# Altium schematic sheet ESP32P4.SchDoc
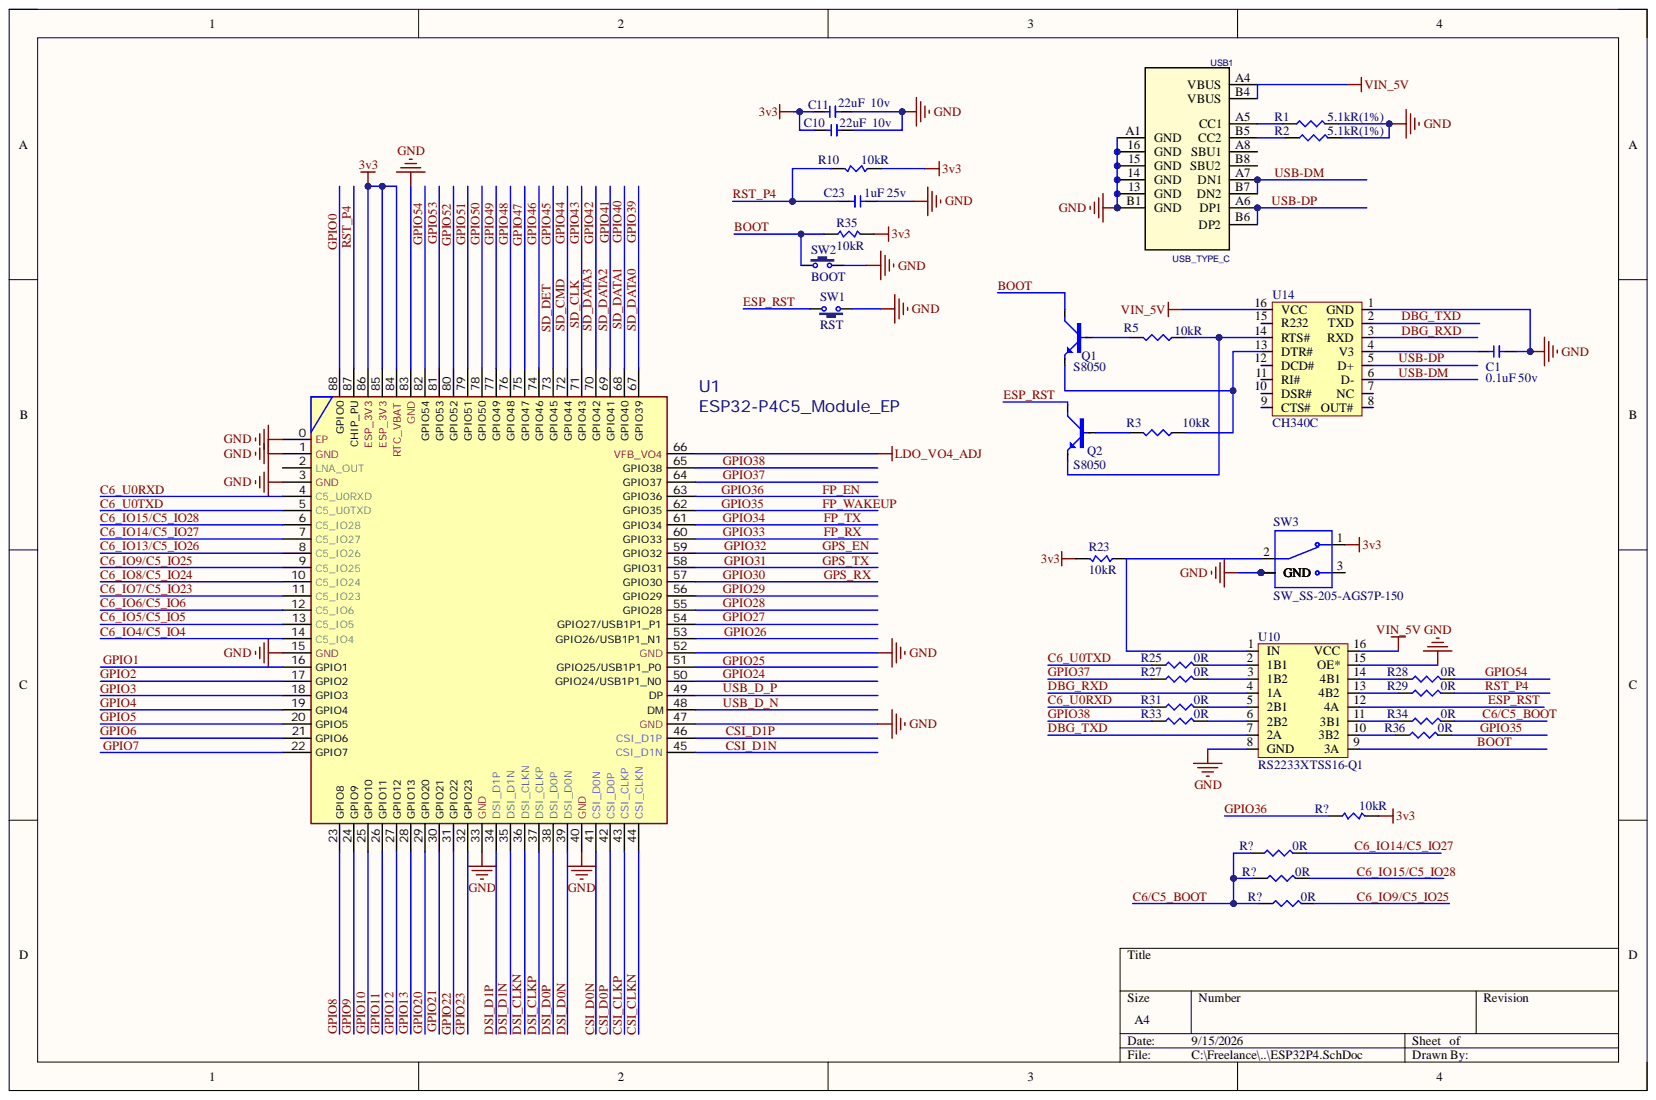
[diagram p0: ESP32P4.SchDoc]

## Parts
C1  0.1uF 50v  [0603C]
C10  22uF  10v  [0603C]
C11  22uF  10v  [0603C]
C23  1uF 25v  [0603C]
Q1  S8050  [sot23-3-TRANSISTOR]
Q2  S8050  [sot23-3-TRANSISTOR]
R1  5.1kR(1%)  [0603]
R2  5.1kR(1%)  [0603]
R3  10kR  [0603]
R5  10kR  [0603]
R10  10kR  [0603]
R23  10kR  [0603]
R25  0R  [0603]
R27  0R  [0603]
R28  0R  [0603]
R29  0R  [0603]
R31  0R  [0603]
R33  0R  [0603]
R34  0R  [0603]
R35  10kR  [0603]
R36  0R  [0603]
R?  0R  [0603]
R?  0R  [0603]
R?  0R  [0603]
R?  10kR  [0603]
SW1  RST  [KEY 3.2*4.2*2.5 4P SMD]
SW2  BOOT  [KEY 3.2*4.2*2.5 4P SMD]
SW3  SW_SS-205-AGS7P-150  [SW_SS-205-AGS7P-150]
U1  ESP32-P4C5_Module_EP  [ESP32P4C5模组_EP]
U10  RS2233XTSS16-Q1  [TSSOP16]
U14  CH340C  [SOP-16]
USB1  USB_TYPE_C  [USB16-typeC_8.94x6.2mm]

## Nets
3v3: C10.2 C11.2 R10.1 R23.2 R35.2 R?.2 SW3.1 U1.84(RTC_VBAT) U1.85(ESP_3V3) U1.86(ESP_3V3)
GND: C1.1 C10.1 C11.1 C23.2 R1.1 R2.1 SW1.1 SW2.2 SW3.4(GND) SW3.5 SW3.6 SW3.7 U1.0(EP) U1.1(GND) U1.3(GND) U1.15(GND) U1.33(GND) U1.40(GND) U1.47(GND) U1.52(GND) U1.83(GND) U10.8(GND) U10.15(OE*) U14.1(GND) USB1.13(GND) USB1.14(GND) USB1.15(GND) USB1.16(GND) USB1.A1(GND) USB1.B1(GND)
LDO_VO4_ADJ: U1.66(VFB_VO4)
VIN_5V: U10.16(VCC) U14.16(VCC) USB1.A4(VBUS) USB1.B4(VBUS)
BOOT: Q1.C R35.1 SW2.1 U10.9(3A)
C6/C5_BOOT: R34.1 R?.2
C6_IO4/C5_IO4: U1.14(C5_IO4)
C6_IO5/C5_IO5: U1.13(C5_IO5)
C6_IO6/C5_IO6: U1.12(C5_IO6)
C6_IO7/C5_IO23: U1.11(C5_IO23)
C6_IO8/C5_IO24: U1.10(C5_IO24)
C6_IO9/C5_IO25: R?.1 U1.9(C5_IO25)
C6_IO13/C5_IO26: U1.8(C5_IO26)
C6_IO14/C5_IO27: R?.1 U1.7(C5_IO27)
C6_IO15/C5_IO28: R?.1 U1.6(C5_IO28)
C6_U0RXD: R31.2 U1.4(C5_U0RXD)
C6_U0TXD: R25.2 U1.5(C5_U0TXD)
CSI_D1N: U1.45(CSI_D1N)
CSI_D1P: U1.46(CSI_D1P)
DBG_RXD: U10.4(1A) U14.3(RXD)
DBG_TXD: U10.7(2A) U14.2(TXD)
ESP_RST: Q2.C SW1.2 U10.12(4A)
FP_EN: R?.1 U1.63(GPIO36)
FP_RX: U1.60(GPIO33)
FP_TX: U1.61(GPIO34)
FP_WAKEUP: R36.1 U1.62(GPIO35)
GPIO0: U1.88(GPIO0)
GPIO1: U1.16(GPIO1)
GPIO2: U1.17(GPIO2)
GPIO3: U1.18(GPIO3)
GPIO4: U1.19(GPIO4)
GPIO7: U1.22(GPIO7)
GPIO8: U1.23(GPIO8)
GPIO9: U1.24(GPIO9)
GPIO10: U1.25(GPIO10)
GPIO11: U1.26(GPIO11)
GPIO12: U1.27(GPIO12)
GPIO13: U1.28(GPIO13)
GPIO20: U1.29(GPIO20)
GPIO21: U1.30(GPIO21)
GPIO24: U1.50(GPIO24/USB1P1_N0)
GPIO26: U1.53(GPIO26/USB1P1_N1)
GPIO27: U1.54(GPIO27/USB1P1_P1)
GPIO28: U1.55(GPIO28)
GPIO29: U1.56(GPIO29)
GPIO30: U1.57(GPIO30)
GPIO31: U1.58(GPIO31)
GPIO32: U1.59(GPIO32)
GPIO37: R27.2 U1.64(GPIO37)
GPIO38: R33.2 U1.65(GPIO38)
GPIO39: U1.67(GPIO39)
GPIO40: U1.68(GPIO40)
GPIO42: U1.70(GPIO42)
GPIO43: U1.71(GPIO43)
GPIO44: U1.72(GPIO44)
GPIO45: U1.73(GPIO45)
GPIO46: U1.74(GPIO46)
GPIO47: U1.75(GPIO47)
GPIO48: U1.76(GPIO48)
GPIO49: U1.77(GPIO49)
GPIO50: U1.78(GPIO50)
GPIO51: U1.79(GPIO51)
GPIO52: U1.80(GPIO52)
GPIO53: U1.81(GPIO53)
GPIO54: R28.1 U1.82(GPIO54)
RST_P4: C23.1 R10.2 R29.1 U1.87(CHIP_PU)
SD_DATA2: U1.69(GPIO41)
USB-DM: U14.6(D-) USB1.A7(DN1) USB1.B7(DN2)
USB-DP: U14.5(D+) USB1.A6(DP1) USB1.B6(DP2)
USB_D_N: U1.48(DM)
USB_D_P: U1.49(DP)
NetC1_2: C1.2 U14.4(V3)
NetQ1_B: Q1.B R5.2
NetQ1_E: Q1.E R3.1 U14.13(DTR#)
NetQ2_B: Q2.B R3.2
NetQ2_E: Q2.E R5.1 U14.14(RTS#)
NetR1_2: R1.2 USB1.A5(CC1)
NetR2_2: R2.2 USB1.B5(CC2)
NetR23_1: R23.1 SW3.2 U10.1(IN)
NetR25_1: R25.1 U10.2(1B1)
NetR27_1: R27.1 U10.3(1B2)
NetR28_2: R28.2 U10.14(4B1)
NetR29_2: R29.2 U10.13(4B2)
NetR31_1: R31.1 U10.5(2B1)
NetR33_1: R33.1 U10.6(2B2)
NetR34_2: R34.2 U10.11(3B1)
NetR36_2: R36.2 U10.10(3B2)
CSI_CLKN:
CSI_CLKP:
CSI_D0N:
CSI_D0P:
DSI_CLKN:
DSI_CLKP:
DSI_D0N:
DSI_D0P:
DSI_D1N:
DSI_D1P:
GPIO5:
GPIO6:
GPIO22:
GPIO23:
GPIO25:
GPIO34:
GPIO41:
SD_DATA3:

Not connected: SW3 3; U1 2(LNA_OUT) 20(GPIO5) 21(GPIO6) 31(GPIO22) 32(GPIO23) 34(DSI_D1P) 35(DSI_D1N) 36(DSI_CLKN) 37(DSI_CLKP) 38(DSI_D0P) 39(DSI_D0N) 41(CSI_D0N) 42(CSI_D0P) 43(CSI_CLKP) 44(CSI_CLKN) 51(GPIO25/USB1P1_P0); U14 7(NC) 8(OUT#) 9(CTS#) 10(DSR#) 11(RI#) 12(DCD#) 15(R232); USB1 A8(SBU1) B8(SBU2)

## Library data of the parts
C1 = CAP: 贴片电容（MLCC） 0603 100nF ±10% 50V X7R; Manufacturer: Yageo(国巨); PartNumber: CC0603KPX7R9BB104; Component Kind: Standard; Library Name: BingRan_2019.SchLib
C10 C11 = CAP: 贴片电容（MLCC） 0603 22µF ±20% 10V Y5V; Manufacturer: FH(风华); PartNumber: 0603F226M100NT; Component Kind: Standard; Library Name: BingRan_2019.SchLib
C23 = CAP: 贴片电容（MLCC） 0603 1µF ±10% 25V X7R; Manufacturer: FH(风华); PartNumber: 0603B105K250NT; Component Kind: Standard; Library Name: BingRan_2019.SchLib
Q1 Q2 = NPN: 通用三极管 NPN 25V 500mA SOT23; Manufacturer: Jingdao(晶导微电子); Component Kind: Standard; PartNumber: S8050; Library Name: BingRan_2019.SchLib
R1 R2 = RES-0603: 贴片电阻 0603 5.1KΩ ±1% 1/16W ±100ppm/℃; Manufacturer: Yageo(国巨); PartNumber: RC0603FR-075K1L; Component Kind: Standard; Library Name: BingRan_2019.SchLib
R3 R5 = RES-0603: 贴片电阻 0603 10KΩ ±1% 1/10W ±150ppm/℃; Manufacturer: Yageo(国巨); PartNumber: RC0603FR-0710KP; Component Kind: Standard; Library Name: BingRan_2019.SchLib
R10 R23 R35 R? = RES: 贴片电阻 0603 10KΩ ±1% 1/10W ±150ppm/℃; Manufacturer: Yageo(国巨); PartNumber: RC0603FR-0710KP; Component Kind: Standard; Library Name: BingRan_2019.SchLib
R25 R27-R29 R31 R33 R34 R36 R? R? R? = RES-0603: 贴片电阻 0603 0Ω ±1% 1/10W; Manufacturer: Yageo(国巨); PartNumber: RC0603FR-070RL; Component Kind: Standard; Library Name: BingRan_2019.SchLib
SW1 SW2 = SW 3x6: 轻触开关 3x6x2.5 立贴 250克力 寿命5万次 50mA 12VDC 白头; Manufacturer: BRIGHT(博瑞泰); PartNumber: TSA363G25-250B; Component Kind: Standard; Library Name: BingRan_2019.SchLib
SW3 = SW_SS-205-AGS7P-150: Slide Switch SPDT Through Hole; MF: XUNPU; Component Kind: Standard; MP: SS-205-AGS7P-150; Library Name: BingRan_2019.SchLib
U10 = RS2233XTSS16-Q1: Wide-Bandwidth 4-Channel SPDT Video Analog Switch; Manufacturer: RUNIC; Component Kind: Standard; PartNumber: RS2233XTSS16-Q1; Library Name: BingRan_2019.SchLib
U14 = CH340C: 2Mbps transceiver USB 2.0 SOP-16 USB Converters ROHS; Manufacturer: WCH(Jiangsu Qin Heng); Component Kind: Standard; PartNumber: CH340C; Library Name: BingRan_2019.SchLib
USB1 = USB_TYPE_C: Manufacturer: BBJconn; PartNumber: UC.01.51-11-0017; Component Kind: Standard; Library Name: BingRan_2019.SchLib
U1 = ESP32-P4C5_Module_EP: Component Kind: Standard; Library Name: BingRan_2019.SchLib
